# Altium schematic sheet Modulo Micro Starter.SchDoc
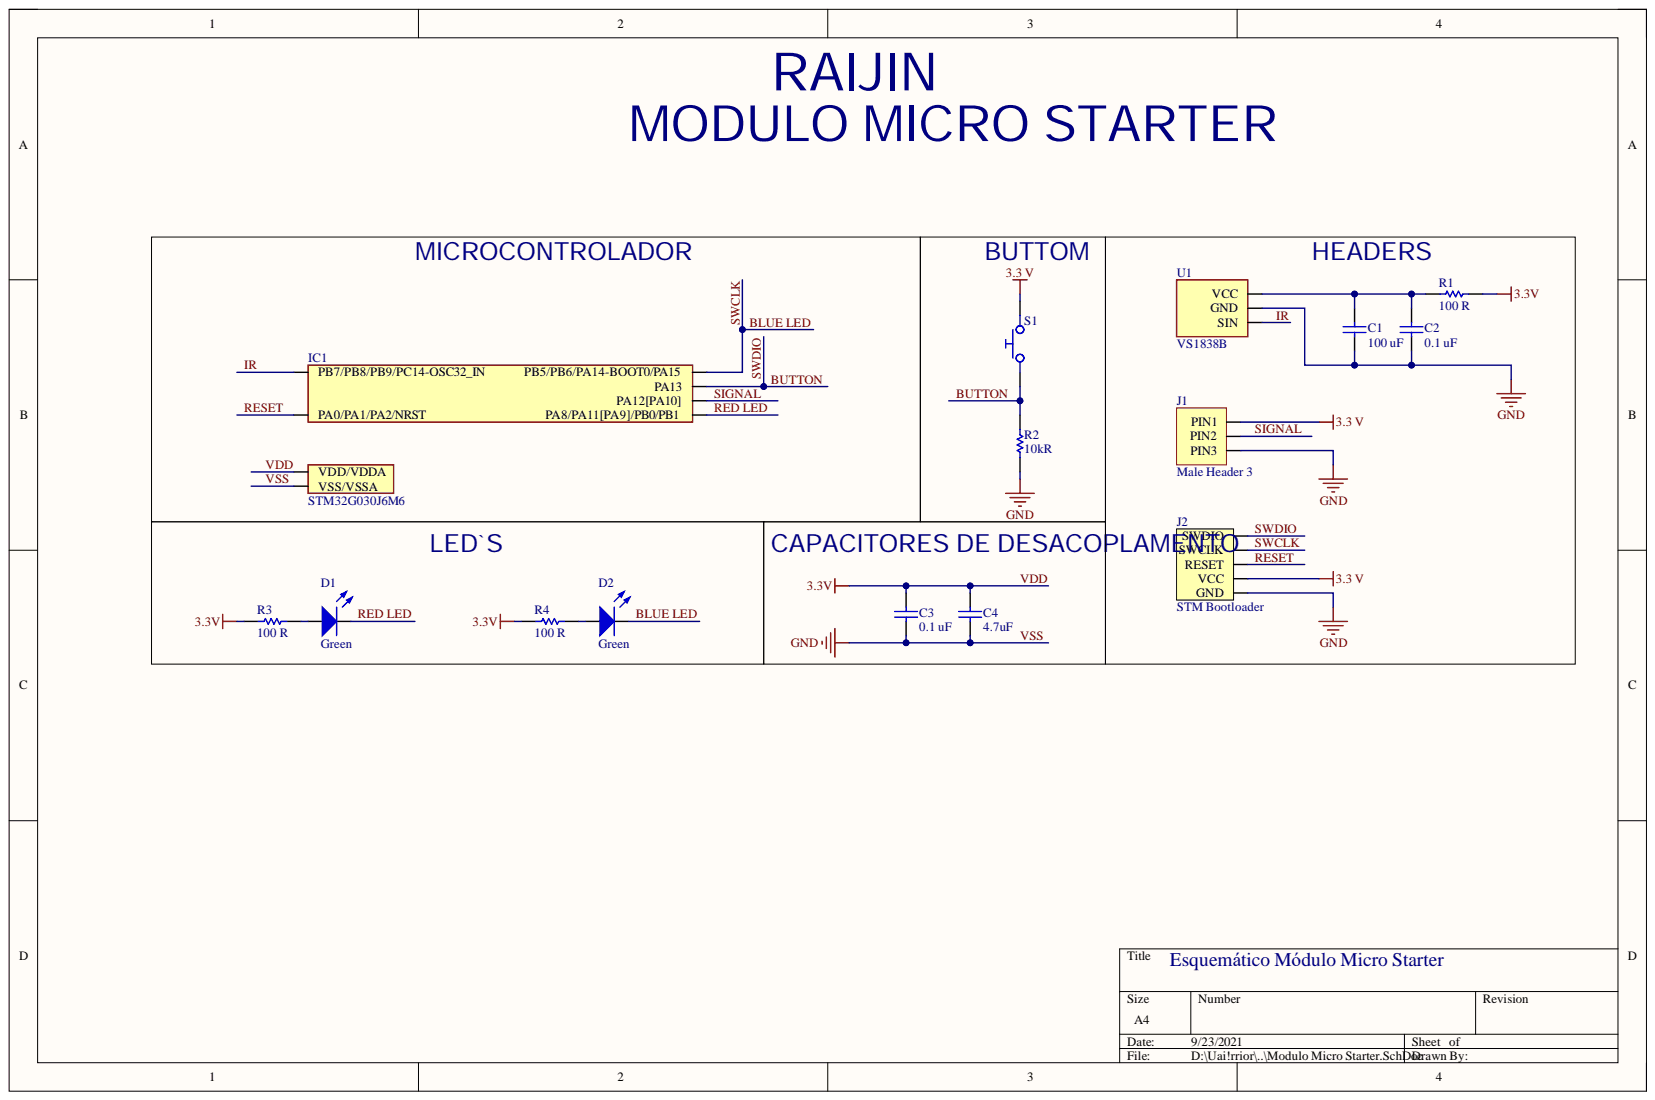
[diagram p0: Modulo Micro Starter.SchDoc]

## Parts
C1  100 uF  [C0805]
C2  0.1 uF  [C0603]
C3  0.1 uF  [C0603]
C4  4.7uF  [C0603]
D1  Green  [LED_0603]
D2  Green  [LED_0603]
IC1  STM32G030J6M6  [SO8N]
J1  Male Header 3  Library Ref: Header  [MALE HEADER 3]
J2  STM Bootloader  [STM BOOTLOADER]
R1  100 R  [RESISTOR_0603]
R2  10kR  [RESISTOR_0603]
R3  100 R  [RESISTOR_0603]
R4  100 R  [RESISTOR_0603]
S1  PTS540JK035SMTRLFS  [PUSH_BUTTON]  (value not drawn)
U1  VS1838B  [FEMALE HEADER 3 PIN]

## Nets
3.3 V: J1.PIN1 J2.VCC S1.2
BLUE LED: D2.C IC1.8(PB5/PB6/PA14-BOOT0/PA15) J2.CLK(SWCLK)
BUTTON: IC1.7(PA13) J2.DIO(SWDIO) R2.2 S1.1
IR: IC1.1(PB7/PB8/PB9/PC14-OSC32_IN) U1.PIN3
RED LED: D1.C IC1.5(PA8/PA11[PA9]/PB0/PB1)
RESET: IC1.4(PA0/PA1/PA2/NRST) J2.RESET
SIGNAL: IC1.6(PA12[PA10]) J1.PIN2
VDD: C3.2 C4.2 IC1.2(VDD/VDDA) R1.2 R3.1 R4.1
VSS: C1.1 C2.1 C3.1 C4.1 IC1.3(VSS/VSSA) J1.PIN3 J2.GND R2.1 U1.PIN2
NetC1_2: C1.2 C2.2 R1.1 U1.PIN1
NetD1_A: D1.A R3.2
NetD2_A: D2.A R4.2

## Library data of the parts
C1 = JMK212BBJ107MG-TE: CAP CER 100UF 6.3V X5R 0805; Manufacturer: TAIYO YUDEN; Part Number: JMK212BBJ107MGTE; Library Control - Checked Footprint: Yes; Library Control - Person that Checked Footprint: Nikaum; Tolerance: 20%; Category: Ceramic Capacitor; Component Kind: Standard; ComponentLink1Description: Datasheet; ComponentLink1URL: https://ds.yuden.co.jp/TYCOMPAS/ut/detail?pn=JMK212BBJ107MG-TE&u=M; ComponentLink2Description: Mouser Link; ComponentLink2URL: https://br.mouser.com/ProductDetail/Taiyo-Yuden/JMK212BBJ107MG-TE?qs=%2Fha2pyFadujnrq1V71i9DT3lBuRfsdZxICgBGkmgMyDSXYhp4%252BfygEm2aQR9aTWJ; Dieletric: X5R; ESR: -; Library Control - Checked Schematic: Yes; Library Control - Last Update: 28/06/2021 00:45; Library Ref: Capacitor; Operating Temperature: -55 C ~ 85 C; Package / Case: 0805 (2012 Metric); Price: 1= 1,81, 10= 1,32, 100= 1,05 (USD); Size / Dimension: 2,00 L x 1,25 W x 1,45 H; Supplier 1: MOUSER; Supplier Part Number 1: 963-JMK212BBJ107MGTE; Value: 100 uF; Voltage - Rated: 6.3V; Library Control - Person that Checked Schematic: Nikaum; Library Control - Person that did the Last Update Footprint: Nikaum; Library Control - Person that did the Last Update Schematic: Nikaum; Library Name: Uai!Tecas.IntLib
C2 C3 = GRM188R71E104KA01D: CAP CER 0.1uF 25V X7R 0603; Manufacturer: Murata Electronics; ESR: 100 mΩ; Library Control - Checked Footprint: Yes; Library Control - Person that Checked Footprint: Nikaum; Library Control - Person that did the Last Update Footprint: Raquel; Tolerance: 10%; Voltage - Rated: 25 VDC; Category: Ceramic Capacitors; Component Kind: Standard; ComponentLink1Description: Datasheet; ComponentLink1URL: https://br.mouser.com/datasheet/2/281/murata_03052018_GRM_Series_1-1310166.pdf; ComponentLink2Description: Mouser Link; ComponentLink2URL: https://br.mouser.com/ProductDetail/Murata-Electronics/GRM188R71E104KA01D?qs=%2Fha2pyFaduiZGTXBCPzk%2FmTbMtAE8bS6%252BO3gVgb8hKBi1xePxGtiOg%3D%3D; Dieletric: X7R; Library Control - Checked Schematic: Yes; Library Control - Last Update: 28/06/2021 00:22; Library Ref: Capacitor; Operating Temperature: -55 C ~ 125 C; Package / Case: 0603 (1608 Metric); Part Number: GRM188R71E104KA01D; Price: -; Size / Dimension: 1,60 L x 0,80 W x 0,80 H; Supplier 1: Mouser; Supplier Part Number 1: 81-GRM39X104K25; Value: 0.1 uF; Library Control - Person that Checked Schematic: Nikaum; Library Control - Person that did the Last Update Schematic: Nikaum; Library Name: Uai!Tecas.IntLib
C4 = CC0603KRX5R8BB475: CAP CER 4.7uF 25V X5R 0603; Manufacturer: Yageo; ESR: 0.05; Library Control - Checked Footprint: Yes; Library Control - Person that Checked Footprint: Nikaum; Tolerance: 10%; Category: Ceramic Capacitor; Component Kind: Standard; ComponentLink1Description: Datasheet; ComponentLink1URL: https://br.mouser.com/datasheet/2/447/yago_s_a0011397150_1-2286655.pdf; ComponentLink2Description: Mouser Link; ComponentLink2URL: https://br.mouser.com/ProductDetail/Yageo/CC0603KRX5R8BB475?qs=%2Fha2pyFaduh%2F7Q8z%252B7Ot0eflSx5gYDgPHryPFxzpgCUeMwc25ZtPlNgXae48%252BTjy; Dieletric: X5R; Library Control - Checked Schematic: Yes; Library Control - Last Update: 27/06/2021 23:47; Library Ref: Capacitor; Operating Temperature: -55 C ~ 125 C; Package / Case: 0603 (1608 Metric); Part Number: CC0603KRX5R8BB475; Price: 1=0.25, 10=0.164, 100=0,093 (USD); Size / Dimension: 1,60 L x 0,80 W x 0,87 H; Supplier 1: Mouser; Supplier Part Number 1: 603-CC0603KRX58BB475; Value: 4.7uF; Voltage - Rated: 25V; Library Control - Person that Checked Schematic: Nikaum; Library Control - Person that did the Last Update Footprint: Nikaum; Library Control - Person that did the Last Update Schematic: Nikaum; Library Name: Uai!Tecas.IntLib
D1 D2 = 150060VS75000: LED 0.072W GREEN 40MCD 0603; Manufacturer: Wurth Elektronik; Current - Forward (If): 20 mA; Library Control - Last Project that Used: Diodo/Led; Library Control - Person that Checked Footprint: Nícolas; Library Control - Person that did the Last Update Footprint: Marcos; Max Current Rating: 100mA; Max Power Dissipation: 72mW; Reverse Voltage: 5V; Viewing Angle: 140°; Voltage - Forward (Vf): 2 V; Wavelength: 570nm; Category: Standard LEDs - SMD; Color: Green; Component Kind: Standard; ComponentLink1Description: Mouser Link; ComponentLink1URL: https://br.mouser.com/ProductDetail/Wurth-Elektronik/150060VS75000?qs=%2Fha2pyFaduhuT%2FKGSijZP0vTyJZ9U993KfsBQSxqATYhY9jnc2c09tXoMoJ3wn4L; ComponentLink2Description: Datasheet Link; ComponentLink2URL: https://br.mouser.com/datasheet/2/445/150060VS75000-1714627.pdf; Library Control - Checked Footprint: 25/07/2021; Library Control - Checked Schematic: 25/07/2021; Library Control - Last Update: 06/08/2021; Library Ref: LED; Luminous Intensity: 40 mcd; Manufacturer Part Number: 150060VS75000; Operating Temperature: -40~85 °C; Package / Case: 0603; Price: 1 = 0.15, 50 = 0.138, 100 = 0.118 (USD); Supplier 1: Mouser; Supplier Part Number 1: 710-150060VS75000; Library Control - Person that Checked Schematic: Nícolas; Library Control - Person that did the Last Update Schematic: Marcos; Library Name: Uai!Tecas.IntLib
IC1 = STM32G030J6M6: IC MCU 32-BIT 8kB FLASH ARM Cortex M0+ 64MHZ  SO8N; Manufacturer: STM; Library Control - Person that did the Last Update Footprint: Marcos; Number of ADC Channels: 4; Number of I2C Channels: 1; Number of I/Os: 5; Number of SPI Channels: 3; Number of Timers/Counters: 2; Oscillator Type: Internal; Peripherals: ADC, Timer, DMA; Program Memory Size: 64kB; Voltage - Supply (Vcc/Vdd): 2 V to 3.6 V; Category: ARM Microcontrollers - MCU; Component Kind: Standard; ComponentLink1Description: Mouser Link; ComponentLink1URL: https://www.mouser.com/ProductDetail/STMicroelectronics/STM32G030J6M6?qs=uwxL4vQweFMe%2Fiu4dY6izA%3D%3D; ComponentLink2Description: Datasheet Link; ComponentLink2URL: https://www.mouser.com/datasheet/2/389/dm00613878-1799578.pdf; Connectivity: -; Core Processor: ARM Cortex M0+; Library Ref: Microcrontrollers; Number of Ethernet Channels: -; Number of UART/USART Channels: 1; Operating Temperature: -40°C to 85°C; Package/Case: SO8N; Part Number: STM32G030J6M6; Price: 1 = 1,46, 10 = 1,31,  100 = 1,02, 1000 = 0,665 (USD); Program Memory Type: Flash; RAM Size: 8k B; Series: STM32G0; Speed: 64MHz; Supplier 1: Mouser; Supplier Part Number 1: 511-STM32G030J6M6; Library Control - Person that did the Last Update Schematic: Marcos; Library Name: Microcontrolador.SchLib
J1 = Male Header 3: Library Control - Person that did the Last Update Schematic: Guilherme; Number of Contacts: 3; System: Connector; Component Kind: Standard; Description: Male Header 3; Library Name: Header.SchLib; Library Control - Persont that did the Last Update Footprint: Guilherme
J2 = Barramento de Gravação STM: BARRAMENTO DE GRAVAÇÃO; Number of Contacts: 5; Component Kind: Standard; Library Ref: Header; Library Name: Uai!Tecas.IntLib
R1 R3 R4 = RC0603FR-10100RL: RES SMD 100 OHM 1% 1/10W 0603; Manufacturer: Yageo; Library Control - Checked Footprint: Yes; Library Control - Person that Checked Footprint: Nikaum; Library Control - Person that did the Last Update Footprint: Nicolas; Power Rating: 100mW (1/10W); Temperature Coefficient: 100 PPM / C; Voltage Rating: 75 V; Category: Thick Film Resistors - SMD; Component Kind: Standard; ComponentLink1Description: Mouser Link; ComponentLink1URL: https://br.mouser.com/ProductDetail/Yageo/RC0603FR-10100RL/?qs=EiqXWrxQq62RG9T9SdQg0g==; ComponentLink2Description: Datasheet Link; ComponentLink2URL: https://br.mouser.com/datasheet/2/447/Yageo_03_18_2021_PYu_RC_Group_51_RoHS_L_11-2199992.pdf; Library Control - Checked Schematic: Yes; Library Control - Last Update: 27/06/2021 21:11; Library Ref: Resistor; Operating Temperature: - 55 C~155 C; Package / Case: 0603 (1608 metric); Part Number: RC0603FR-10100RL; Price: 1 = 0,10, 10 = 0,018, 100 = 0,006 (USD); Resistor Type: Fixo; Size / Dimension: 1,60 L x 0,80 W x 0,45 H; Supplier 1: Mouser; Supplier Part Number 1: 603-RC0603FR-10100RL; Tolerance: 1 %; Value: 100 R; Library Control - Person that Checked Schematic: Nikaum; Library Control - Person that did the Last Update Schematic: Nikaum; Library Name: Uai!Tecas.IntLib
R2 = RC0603JR-0710KL: RES SMD 10K OHM 5% 1/10W 0603; Manufacturer: Yageo; Library Control - Checked Footprint: No; Library Control - Checked Schematic: Yes; Library Control - Person that Checked Schematic: Marcos; Library Control - Person that did the Last Update Footprint: Dalarme; Power Rating: 100 mW (1/10 W); Temperature Coefficient: 100 PPM / C; Voltage Rating: 75 V; Category: Thick Film Resistors - SMD; Component Kind: Standard; ComponentLink1Description: Mouser Link; ComponentLink1URL: https://br.mouser.com/ProductDetail/Yageo/RC0603JR-0710KL?qs=ksOUTF%2FWcd8D6T22BJkQ7g%3D%3D; ComponentLink2Description: Datsheet Link; ComponentLink2URL: https://br.mouser.com/datasheet/2/447/Yageo_03_18_2021_PYu_RC_Group_51_RoHS_L_11-2199992.pdf; Library Control - Last Update: 21/06/21 14:15; Library Ref: Resistor; Operating Temperature: - 55ºC ~ 155ºC; Package / Case: 0603 (1608 Metric); Part Number: RC0603JR-0710KL; Price: 1=0,10, 10=0,015, 100=0,005 1000=0,0040 (USD); Resistor Type: Fixo; Size / Dimension: 1.6 mm L x  0.8 mm W x  0.45 mm H; Supplier 1: Mouser; Supplier Part Number 1: 603-RC0603JR-0710KL; Tolerance: 5 %; Value: 10kR; Library Control - Person that did the Last Update Schematic: Marcos; Library Name: Uai!Tecas.IntLib
S1 = Push Button: TACTILE SWITCH SPST 0.05A 12VDC SMD; Manufacturer: C&K Switches; Bounce time (MAX): 10ms; Current Rating: 50mA; Library Control - Checked Footprint: Yes; Library Control - Person that Checked Footprint: Nícolas; Operating Force: 230gf; Switch Function: Off-On; Voltage Rating (DC): 12V; Category: Tactile Switches; Component Kind: Standard; ComponentLink1Description: Datasheet; ComponentLink1URL: https://www.mouser.com/datasheet/2/60/pts540-1841856.pdf; ComponentLink2Description: Mouser Link; ComponentLink2URL: https://www.mouser.com/ProductDetail/CK/PTS540JK035SMTRLFS?qs=nsc5Ve2%252BdoHscnqTjIh87g%3D%3D; Library Control - Checked Schematic: Yes; Library Ref: Diversos; Orientation: Straight; Part Number: PTS540JK035SMTRLFS; Pricing 1: 1=0,18, 10=0,174, 100=0,15, 500=0,122, 1000=0,103 (USD); Supplier 1: Mouser; Supplier Part Number 1: 611-PTS540JK035SMTRL; Throw Configuration: SPST; Library Control - Person that Checked Schematic: Nícolas; Library Control - Person that did the Last Update Schematic: Nícolas; Library Name: Uai!Tecas.IntLib
U1 = VS1838B: INFRARED RECEIVER MODULE; Library Control - Person that did the Last Update in Footprint: Marcos; Operating Supply Current: 0.4 mA; Output Type: Digital; Sensitivity: +/- 45°; Supply Voltage (Min - Máx): (2.7 - 5.5)V; Component Kind: Standard; ComponentLink1Description: Datasheet; ComponentLink1URL: https://datasheetspdf.com/pdf-file/700398/ETC/VS1838B/1; ComponentLink2Description: Mouser Link; ComponentLink2URL: -; Library Control - Last Update: 06/08/2021; Library Ref: Diversos; Manufacturer: -; Operating Temperature (Min - Máx): -20~80 °C; Package / Case: -; Part Number: VS1838B; Price: -; Sensor Type: Infrared; Supplier 1: -; Library Control - Person that did the Last Update in Schematic: Marcos; Library Name: Uai!Tecas.IntLib
